# Altium schematic sheet Connectors.SchDoc
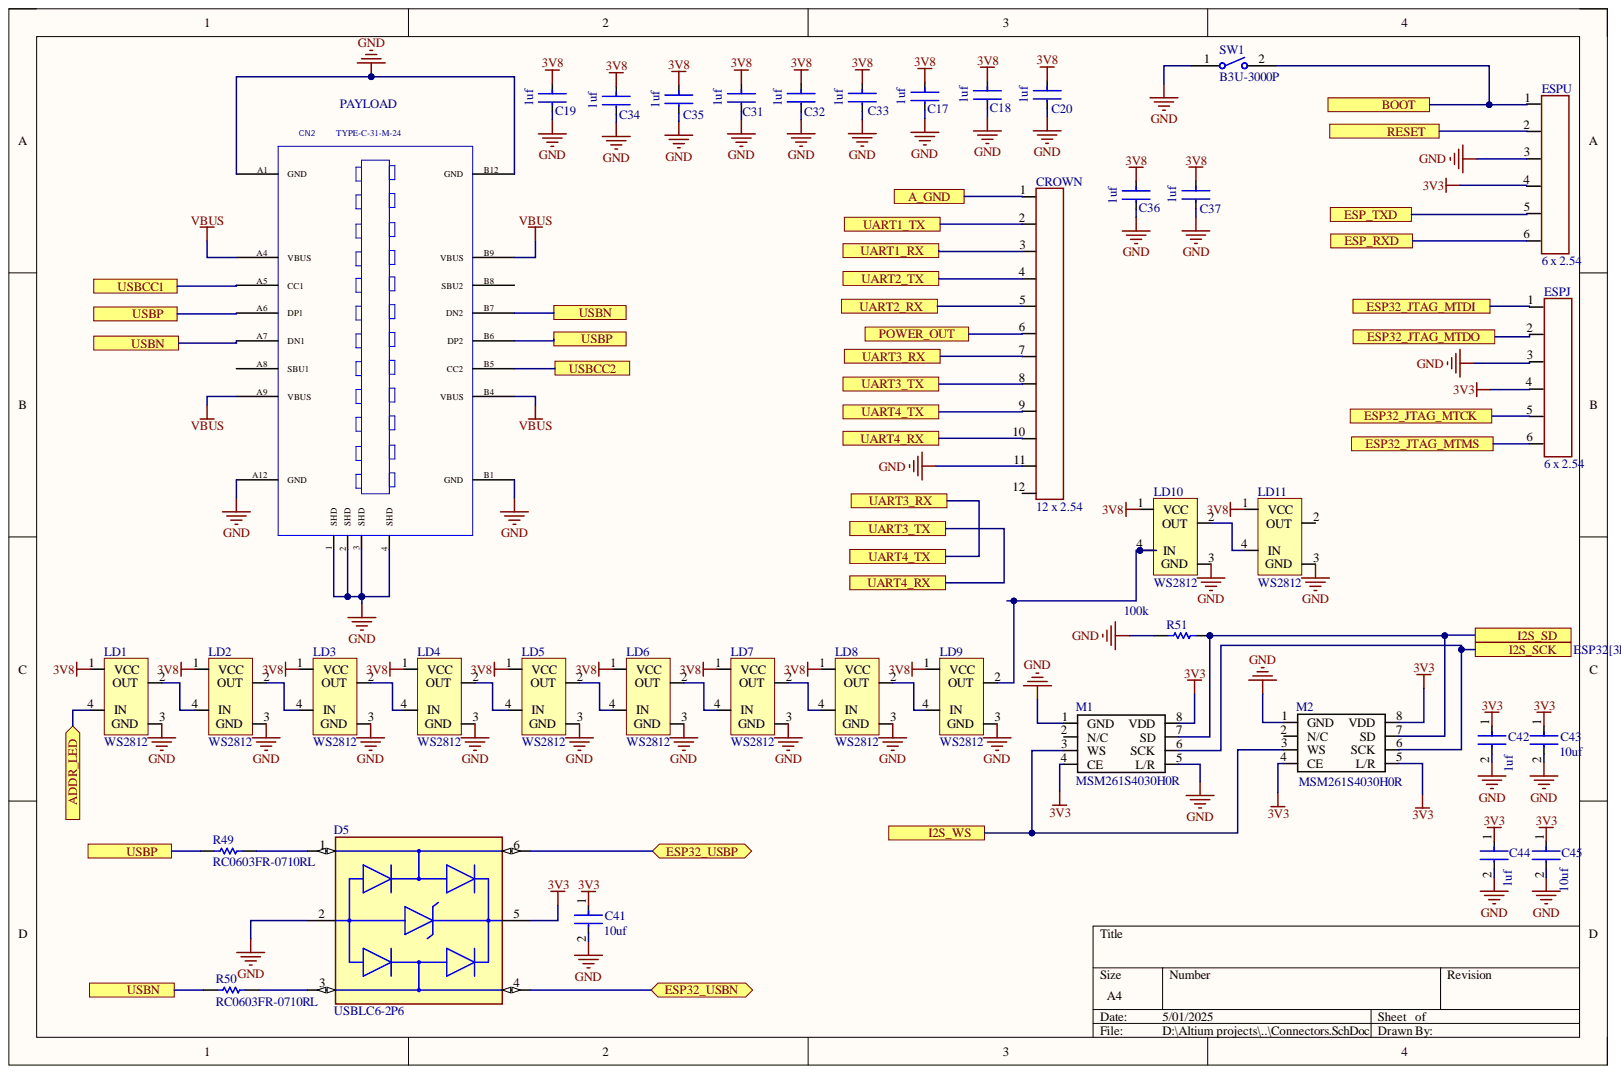
[diagram p0: Connectors.SchDoc]

## Parts
C17  1uf  [FP-0603-L_1_6_0_15-W_0_8-IPC_B]
C18  1uf  [FP-0603-L_1_6_0_15-W_0_8-IPC_B]
C19  1uf  [FP-0603-L_1_6_0_15-W_0_8-IPC_B]
C20  1uf  [FP-0603-L_1_6_0_15-W_0_8-IPC_B]
C31  1uf  [FP-0603-L_1_6_0_15-W_0_8-IPC_B]
C32  1uf  [FP-0603-L_1_6_0_15-W_0_8-IPC_B]
C33  1uf  [FP-0603-L_1_6_0_15-W_0_8-IPC_B]
C34  1uf  [FP-0603-L_1_6_0_15-W_0_8-IPC_B]
C35  1uf  [FP-0603-L_1_6_0_15-W_0_8-IPC_B]
C36  1uf  [FP-0603-L_1_6_0_15-W_0_8-IPC_B]
C37  1uf  [FP-0603-L_1_6_0_15-W_0_8-IPC_B]
C41  10uf  [FP-0603-L_1_6_0_15-W_0_8-IPC_B]
C42  1uf  [FP-0603-L_1_6_0_15-W_0_8-IPC_B]
C43  10uf  [FP-0603-L_1_6_0_15-W_0_8-IPC_B]
C44  1uf  [FP-0603-L_1_6_0_15-W_0_8-IPC_B]
C45  10uf  [FP-0603-L_1_6_0_15-W_0_8-IPC_B]
CN2  value TYPE-C-31-M-24  [USB#UBC019-12P-D95]  (hidden comment: USB#UBC019-12P-D95)
CROWN  12 x 2.54  [DIP24]
D5  USBLC6-2P6  [FP-SOT-666-MFG]
ESPJ  6 x 2.54  [DIP12]
ESPU  6 x 2.54  [DIP12]
LD1  WS2812  [WS2812_3030]
LD2  WS2812  [WS2812_3030]
LD3  WS2812  [WS2812_3030]
LD4  WS2812  [WS2812_3030]
LD5  WS2812  [WS2812_3030]
LD6  WS2812  [WS2812_3030]
LD7  WS2812  [WS2812_3030]
LD8  WS2812  [WS2812_3030]
LD9  WS2812  [WS2812_3030]
LD10  WS2812  [WS2812_3030]
LD11  WS2812  [WS2812_3030]
M1  MSM261S4030H0R  [LGA8]
M2  MSM261S4030H0R  [LGA8]
R49  RC0603FR-0710RL  [RESC1608X55X25ML10T15]
R50  RC0603FR-0710RL  [RESC1608X55X25ML10T15]
R51  100k  [RESC1609X50X30NL8T20]
SW1  B3U-3000P  [OMRON-B3U-3000P_V]

## Ports
A_GND, ADDR_LED, BOOT, ESP32_JTAG_MTCK, ESP32_JTAG_MTDI, ESP32_JTAG_MTDO, ESP32_JTAG_MTMS, ESP32_USBN, ESP32_USBP, ESP_RXD, ESP_TXD, I2S_SCK, I2S_SD, I2S_WS, POWER_OUT, RESET, UART1_RX, UART1_TX, UART2_RX, UART2_TX, UART3_RX, UART3_TX, UART4_RX, UART4_TX, USBCC1, USBCC2, USBN, USBP

## Nets
3V3: C41.1 C42.1 C43.1 C44.1 C45.1 D5.5 ESPJ.4 ESPU.4 M1.4(CE) M1.8(VDD) M2.4(CE) M2.5(L/R) M2.8(VDD)
3V8: C17.1 C18.1 C19.1 C20.1 C31.1 C32.1 C33.1 C34.1 C35.1 C36.1 C37.1 LD1.1(VCC) LD2.1(VCC) LD3.1(VCC) LD4.1(VCC) LD5.1(VCC) LD6.1(VCC) LD7.1(VCC) LD8.1(VCC) LD9.1(VCC) LD10.1(VCC) LD11.1(VCC)
GND: C17.2 C18.2 C19.2 C20.2 C31.2 C32.2 C33.2 C34.2 C35.2 C36.2 C37.2 C41.2 C42.2 C43.2 C44.2 C45.2 CN2.1(SHD) CN2.2(SHD) CN2.3(SHD) CN2.4(SHD) CN2.A1(GND) CN2.A12(GND) CN2.B1(GND) CN2.B12(GND) CROWN.11 D5.2 ESPJ.3 ESPU.3 LD1.3(GND) LD2.3(GND) LD3.3(GND) LD4.3(GND) LD5.3(GND) LD6.3(GND) LD7.3(GND) LD8.3(GND) LD9.3(GND) LD10.3(GND) LD11.3(GND) M1.1(GND) M1.5(L/R) M2.1(GND) R51.1 SW1.1
VBUS: CN2.A4(VBUS) CN2.A9(VBUS) CN2.B4(VBUS) CN2.B9(VBUS)
A_GND: CROWN.1 ; port A_GND
ADDR_LED: LD1.4(IN) ; port ADDR_LED
BOOT: ESPU.1 SW1.2 ; port BOOT
ESP32_JTAG_MTCK: ESPJ.5 ; port ESP32_JTAG_MTCK
ESP32_JTAG_MTDI: ESPJ.1 ; port ESP32_JTAG_MTDI
ESP32_JTAG_MTDO: ESPJ.2 ; port ESP32_JTAG_MTDO
ESP32_JTAG_MTMS: ESPJ.6 ; port ESP32_JTAG_MTMS
ESP32_USBN: D5.4 ; port ESP32_USBN
ESP32_USBP: D5.6 ; port ESP32_USBP
ESP_RXD: ESPU.6 ; port ESP_RXD
ESP_TXD: ESPU.5 ; port ESP_TXD
I2S_SCK: M1.6(SCK) M2.6(SCK) ; port I2S_SCK
I2S_SD: M1.7(SD) M2.7(SD) R51.2 ; port I2S_SD
I2S_WS: M1.3(WS) M2.3(WS) ; port I2S_WS
POWER_OUT: CROWN.6 ; port POWER_OUT
RESET: ESPU.2 ; port RESET
UART1_RX: CROWN.3 ; port UART1_RX
UART1_TX: CROWN.2 ; port UART1_TX
UART2_RX: CROWN.5 ; port UART2_RX
UART2_TX: CROWN.4 ; port UART2_TX
UART3_RX: CROWN.7 CROWN.9 ; port UART3_RX, port UART4_TX
UART3_TX: CROWN.8 CROWN.10 ; port UART3_TX, port UART4_RX
USBCC1: CN2.A5(CC1) ; port USBCC1
USBCC2: CN2.B5(CC2) ; port USBCC2
USBN: CN2.A7(DN1) CN2.B7(DN2) R50.1 ; port USBN
USBP: CN2.A6(DP1) CN2.B6(DP2) R49.1 ; port USBP
NetD5_1: D5.1 R49.2
NetD5_3: D5.3 R50.2
NetLD1_2: LD1.2(OUT) LD2.4(IN)
NetLD2_2: LD2.2(OUT) LD3.4(IN)
NetLD3_2: LD3.2(OUT) LD4.4(IN)
NetLD4_2: LD4.2(OUT) LD5.4(IN)
NetLD5_2: LD5.2(OUT) LD6.4(IN)
NetLD6_2: LD6.2(OUT) LD7.4(IN)
NetLD7_2: LD7.2(OUT) LD8.4(IN)
NetLD8_2: LD8.2(OUT) LD9.4(IN)
NetLD9_2: LD9.2(OUT) LD10.4(IN)
NetLD10_2: LD10.2(OUT) LD11.4(IN)

Not connected: CN2 A8(SBU1) B8(SBU2); CROWN 12; LD11 2(OUT); M1 2(N/C); M2 2(N/C)

## Library data of the parts
C17-C20 C31-C37 C42 C44 = CMP-2000-07531-4: Ceramic Capacitor General Use 1uF ±10% 50V X7R 0603; Capacitance: 1uF; Case Code (Imperial): 0603; Case Code (Metric): 1608; Case/Package: 0603; Component Kind: Standard; Construction: Flat; Depth: 0.8mm; Dielectric: X7R; Halogen Free: Halogen Free; Height: 0.8mm; Length: 1.6mm; Library Name: Altium Content Vault; Max Operating Temperature: 125°C; Min Operating Temperature: -55°C; Mount: Surface Mount; Package Quantity: 1; Packaging: Tape and Reel; Radiation Hardening: No; RoHS Compliant: Yes; Series: M; Termination: SMD/SMT; Thickness: 0.037inch; Tolerance: 10%; Voltage Rating: 50V; Voltage Rating (DC): 50V; Width: 0.031inch
C41 C43 C45 = CMP-2000-07531-4: Ceramic Capacitor General Use 10uF ±10% 50V X7R 0603; Capacitance: 1uF; Case Code (Imperial): 0603; Case Code (Metric): 1608; Case/Package: 0603; Component Kind: Standard; Construction: Flat; Depth: 0.8mm; Dielectric: X7R; Halogen Free: Halogen Free; Height: 0.8mm; Length: 1.6mm; Library Name: Altium Content Vault; Max Operating Temperature: 125°C; Min Operating Temperature: -55°C; Mount: Surface Mount; Package Quantity: 1; Packaging: Tape and Reel; Radiation Hardening: No; RoHS Compliant: Yes; Series: M; Termination: SMD/SMT; Thickness: 0.037inch; Tolerance: 10%; Voltage Rating: 50V; Voltage Rating (DC): 50V; Width: 0.031inch
CN2 = USB#UBC019-12P-D95: USB TYPE-С 12PIN SMT L=6.5mm,H=3.2mm; Manufacture: JM-LINK; Geometry.Height: 3.2mm; Component Kind: Standard; Library Name: Schlib1.SchLib; PCB DECAL: USB#UBC019-12P-D95
CROWN = 12x2,54: 2.54 connector; Component Kind: Standard; Library Name: connectors.SchLib
D5 = CMP-12225-000058-1: TVS DIODE 5.25V 17V SOT666; Breakdown Voltage: 6V; Capacitance: 3.5pF; Direction: Unidirectional; Element Configuration: Dual; ESD Protection: Yes; Leakage Current: 150nA; Number of Bidirectional Channels: 2; Number of Elements: 1; Operating Supply Voltage: 5V; Peak Pulse Current: 5A; Test Current: 1mA; Case/Package: SOT-666; Clamping Voltage: 17V; Component Kind: Standard; Contact Plating: Tin; Depth: 1.3mm; Height: 0.6mm; Lead Free: Lead Free; Length: 1.7mm; Library Name: Altium Content Vault; Max Breakdown Voltage: 6V; Max Operating Temperature: 125°C; Max Reverse Leakage Current: 150nA; Min Breakdown Voltage: 6V; Min Operating Temperature: -40°C; Mount: Surface Mount; Number of Channels: 2; Number of Pins: 6; Package Quantity: 1; Packaging: Tape and Reel; Polarity: Unidirectional; Power Line Protection: Yes; Radiation Hardening: No; REACH SVHC: No SVHC; Reverse Breakdown Voltage: 6V; Reverse Standoff Voltage: 5V; Termination: SMD/SMT; Width: 1.3mm; Working Voltage: 5V
ESPJ ESPU = 6x2,54: 2.54 connector; Component Kind: Standard; Library Name: connectors.SchLib
LD1-LD11 = ADDR_LED: Component Kind: Standard; Description: WS2812; Library Name: Schlib1.SchLib
M1 M2 = MSM261S4030H0R: I2S microphone  MSM261S4030H0R; Component Kind: Standard; Library Name: MSM261S4030H0R.SchLib
R49 R50 = CMP-1659-00008-4: Chip Resistor, 10 Ohm, +/- 1%, 0.1 W, -55 to 155 degC, 0603 (1608 Metric), RoHS, Tape and Reel; Manufacturer: Yageo; Voltage Rating: 50 V; Component Kind: Standard; ComponentLink1Description: Manufacturer URL; ComponentLink1URL: http://www.yageo.com/; ComponentLink2Description: Datasheet; ComponentLink2URL: http://www.yageo.com/documents/recent/PYu-RC_Group_51_RoHS_L_04.pdf; ComponentLink3Description: Package Specification; ComponentLink3URL: http://www.farnell.com/datasheets/443971.pdf; DatasheetVersion: Rev. 4, 02/2016; Height: 0.45 mm; Length: 1.6 mm; Library Name: Altium Content Vault; Max Operating Temperature: 155 degC; Min Operating Temperature: -55 degC; Mount: Surface Mount; Mounting Technology: Surface Mount; Package Quantity: 200; PackageDescription: 2-Pin Surface Mount Device, Body 1.6 x 0.8 mm; PackageReference: 0603; Packaging: Tape and Reel; Pins: 2; REACH SVHC: No SVHC; RoHSCompliant: true
R51 = CMP-1666-00005-4: Chip Resistor, 100 KOhm, +/- 1%, 100 mW, -55 to 155 degC, 0603 (1608 Metric), RoHS, Tape and Reel; Manufacturer: Vishay Semiconductor; Voltage Rating: 75 V; Component Kind: Standard; ComponentLink1Description: Manufacturer URL; ComponentLink1URL: http://www.vishay.com/; ComponentLink2Description: Datasheet; ComponentLink2URL: https://datasheet.ciiva.com/11809/0900766b80d98afc-11809037.pdf; DatasheetVersion: 06/2012; Height: 0.45 mm; Length: 1.55 mm; Library Name: Altium Content Vault; Max Operating Temperature: 155 degC; Min Operating Temperature: -55 degC; Mounting Technology: Surface Mount; Package Quantity: 5000; PackageDescription: 2-Pin Surface Mount Device, Body 1.575 x 0.85 mm; PackageReference: 0603; Packaging: Tape and Reel; REACH SVHC: Unknown; RoHSCompliant: true
SW1 = CMP-1677-00003-1: Ultra-Small Tactile Switch, 1 to 50 mA, 3 to 12 V, -25 to 70 degC, 2-Pin SMD, RoHS, Tape and Reel; Capacitance: 470 uF; Case\Package: Radial; Tolerance: 20%; Voltage Rating: 50 V; Component Kind: Standard; ComponentLink1Description: Manufacturer URL; ComponentLink1URL: http://www.omron.com/; ComponentLink2Description: Datasheet; ComponentLink2URL: https://www.omron.com/ecb/products/pdf/en-b3u.pdf; DatasheetVersion: Jan-15; Diameter: 10 mm; Height: 20 mm; Lead Pitch: 5 mm; Length: 20 mm; Library Name: Altium Content Vault; Max Operating Temperature: 85 degC; Min Operating Temperature: -40 degC; Mount: Through Hole; Package Quantity: 200; PackageDescription: 2-Pin Surface Mount Device, Body 4 x 3.2 mm; PackageReference: B3U-3000P; Packaging: Bulk; Pins: 2; Radiation Hardening: No; REACH SVHC: No SVHC; RoHSCompliant: true; Voltage Rating (DC): 50 V
